# Altium schematic sheet Single Channel Isolated Gate Driver Test Board.SchDot
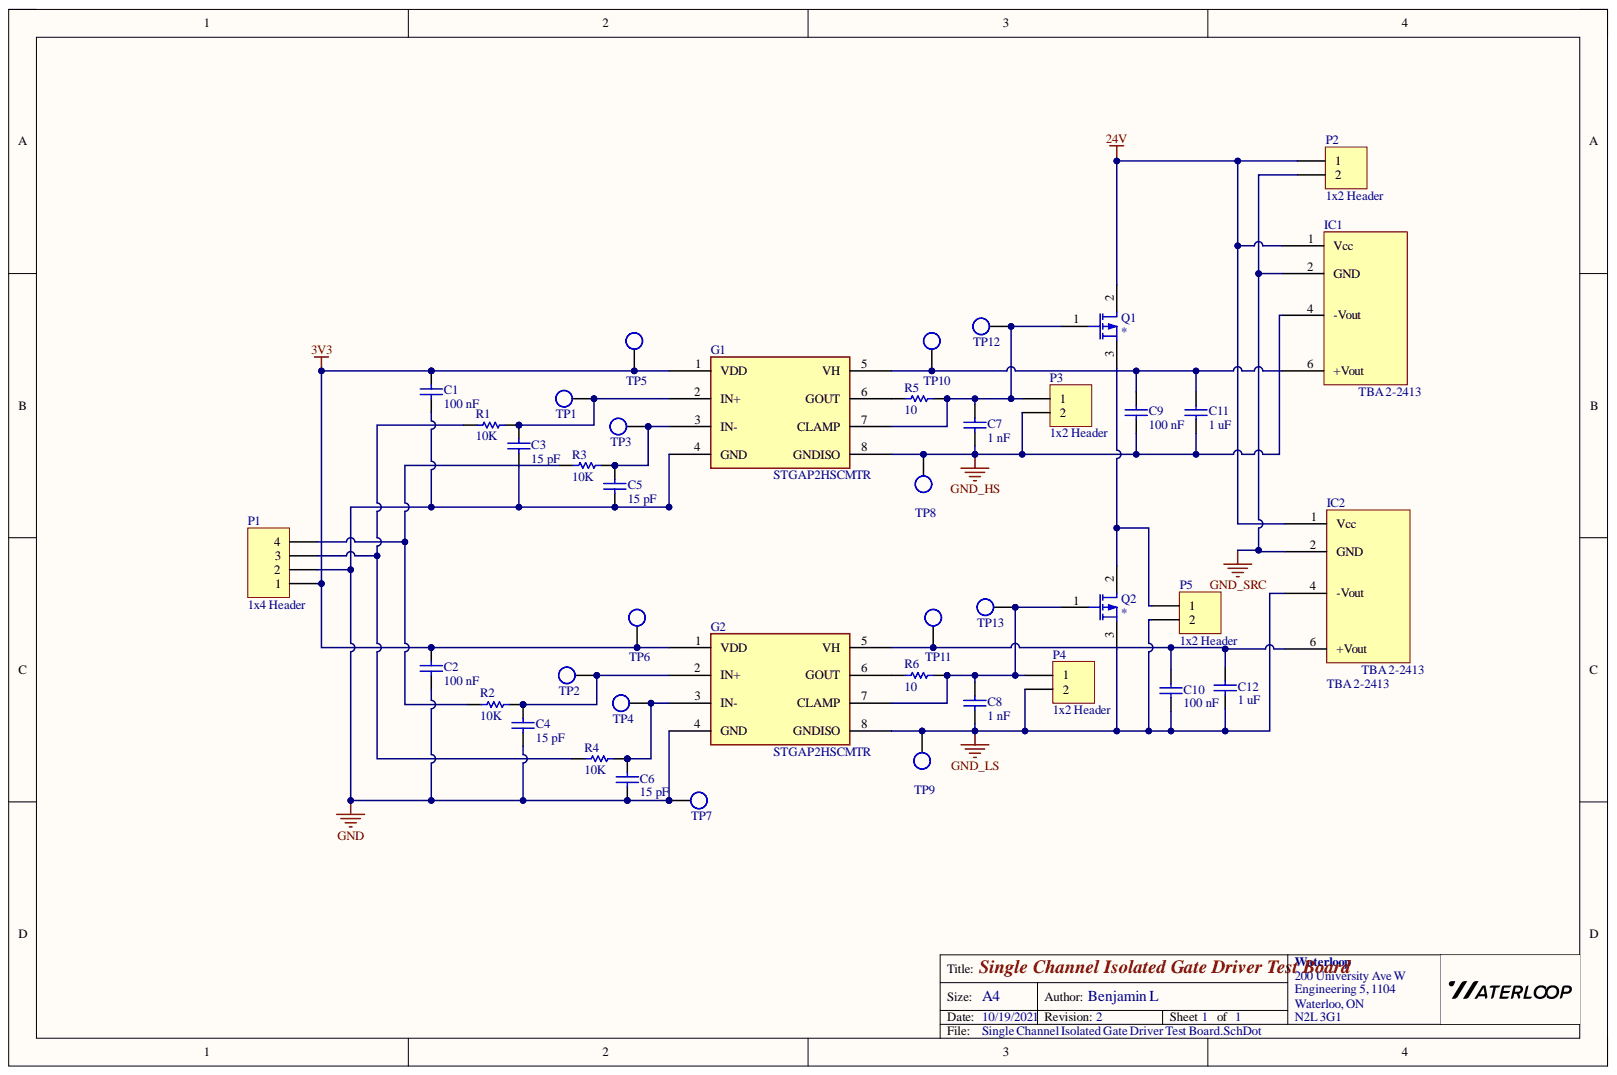
[diagram p0: Single Channel Isolated Gate Driver Test Board.SchDot]

## Parts
C1  value 100 nF  [0805 - Capacitor]  (hidden comment: Capacitor - Nonpolarized)
C2  value 100 nF  [0805 - Capacitor]  (hidden comment: Capacitor - Nonpolarized)
C3  value 15 pF  [0805 - Capacitor]  (hidden comment: Capacitor - Nonpolarized)
C4  value 15 pF  [0805 - Capacitor]  (hidden comment: Capacitor - Nonpolarized)
C5  value 15 pF  [0805 - Capacitor]  (hidden comment: Capacitor - Nonpolarized)
C6  value 15 pF  [0805 - Capacitor]  (hidden comment: Capacitor - Nonpolarized)
C7  value 1 nF  [0805 - Capacitor]  (hidden comment: Capacitor - Nonpolarized)
C8  value 1 nF  [0805 - Capacitor]  (hidden comment: Capacitor - Nonpolarized)
C9  value 100 nF  [0805 - Capacitor]  (hidden comment: Capacitor - Nonpolarized)
C10  value 100 nF  [0805 - Capacitor]  (hidden comment: Capacitor - Nonpolarized)
C11  value 1 uF  [0805 - Capacitor]  (hidden comment: Capacitor - Nonpolarized)
C12  value 1 uF  [0805 - Capacitor]  (hidden comment: Capacitor - Nonpolarized)
G1  STGAP2HSCMTR  [STGAP2HSCMTR]
G2  STGAP2HSCMTR  [STGAP2HSCMTR]
IC1  TBA 2-2413  [TBA 2-2413]
IC2  TBA 2-2413  [TBA 2-2413]
P1  1x4 Header  [HDR1X4]
P2  1x2 Header  [HDR1X2]
P3  1x2 Header  [HDR1X2]
P4  1x2 Header  [HDR1X2]
P5  1x2 Header  [HDR1X2]
Q1  MOSFET-P  [TO2520AB]  (value not drawn)
Q2  MOSFET-P  [TO2520AB]  (value not drawn)
R1  value 10K  [0603 - Resistor]  (hidden comment: Resistor)
R2  value 10K  [0603 - Resistor]  (hidden comment: Resistor)
R3  value 10K  [0603 - Resistor]  (hidden comment: Resistor)
R4  value 10K  [0603 - Resistor]  (hidden comment: Resistor)
R5  value 10  [1206 - Resistor]  (hidden comment: Resistor)
R6  value 10  [1206 - Resistor]  (hidden comment: Resistor)
TP1  Test Point  [Test Point]  (value not drawn)  (no BOM)
TP2  Test Point  [Test Point]  (value not drawn)  (no BOM)
TP3  Test Point  [Test Point]  (value not drawn)  (no BOM)
TP4  Test Point  [Test Point]  (value not drawn)  (no BOM)
TP5  Test Point  [Test Point]  (value not drawn)  (no BOM)
TP6  Test Point  [Test Point]  (value not drawn)  (no BOM)
TP7  Test Point  [Test Point]  (value not drawn)  (no BOM)
TP8  Test Point  [Test Point]  (value not drawn)  (no BOM)
TP9  Test Point  [Test Point]  (value not drawn)  (no BOM)
TP10  Test Point  [Test Point]  (value not drawn)  (no BOM)
TP11  Test Point  [Test Point]  (value not drawn)  (no BOM)
TP12  Test Point  [Test Point]  (value not drawn)  (no BOM)
TP13  Test Point  [Test Point]  (value not drawn)  (no BOM)

## Nets
3V3: C1.1 C2.1 G1.1(VDD) G2.1(VDD) P1.1 TP5.1 TP6.1
24V: IC1.1(Vcc) IC2.1(Vcc) P2.1 Q1.2
GND: C1.2 C2.2 C3.1 C4.1 C5.1 C6.1 G1.4(GND) G2.4(GND) P1.2 TP7.1
GND_HS: C7.1 C9.1 C11.1 G1.8(GNDISO) IC1.4(-Vout) P3.2 TP8.1
GND_LS: C8.1 C10.1 C12.1 G2.8(GNDISO) IC2.4(-Vout) P4.2 P5.2 Q2.3 TP9.1
GND_SRC: IC1.2(GND) IC2.2(GND) P2.2
NetC3_2: C3.2 G1.2(IN+) R1.2 TP1.1
NetC4_2: C4.2 G2.2(IN+) R2.2 TP2.1
NetC5_2: C5.2 G1.3(IN-) R3.2 TP3.1
NetC6_2: C6.2 G2.3(IN-) R4.2 TP4.1
NetC7_2: C7.2 G1.7(CLAMP) P3.1 Q1.1 R5.2 TP12.1
NetC8_2: C8.2 G2.7(CLAMP) P4.1 Q2.1 R6.2 TP13.1
NetC9_2: C9.2 C11.2 G1.5(VH) IC1.6(+Vout) TP10.1
NetC10_2: C10.2 C12.2 G2.5(VH) IC2.6(+Vout) TP11.1
NetG1_6: G1.6(GOUT) R5.1
NetG2_6: G2.6(GOUT) R6.1
NetP1_3: P1.3 R1.1 R4.1
NetP1_4: P1.4 R2.1 R3.1
NetP5_1: P5.1 Q1.3 Q2.2

## Library data of the parts
C1 C2 C9 C10 = Capacitor - Nonpolarized: Nonpolarized Capacitor; Value: 100 nF; Component Kind: Standard; Library Name: General.SchLib; Signal Integrity: Cap; Simulation: CAP
C3 C6 = Capacitor - Nonpolarized: Nonpolarized Capacitor; Value: 15 pF; Component Kind: Standard; Library Name: General.SchLib; Signal Integrity: Cap; Simulation: CAP
C4 C5 C7 C8 C11 C12 = Capacitor - Nonpolarized: Nonpolarized Capacitor; Component Kind: Standard; Library Name: General.SchLib; Signal Integrity: Cap; Simulation: CAP
IC1 IC2 = TBA 2-2413: DC DC CONVERTER 15V 2W; Component Kind: Standard; Library Name: MC.SchLib
P1 = 1x4 Header: 1x4 Pin Header; Component Kind: Standard; Library Name: GeneralConnectors.SchLib; Signal Integrity: Connector
P2-P5 = 1x2 Header: 1x2 Pin Header; Component Kind: Standard; Library Name: GeneralConnectors.SchLib; Signal Integrity: Connector
Q1 Q2 = MOSFET-P: P-Channel MOSFET; Component Kind: Standard; Library Name: General.SchLib; Simulation: PMOS
G1 G2 = STGAP2HSCMTR: Component Kind: Standard; Library Name: General.SchLib
R1-R6 = Resistor: Component Kind: Standard; Description: Resistor; Library Name: General.SchLib; Signal Integrity: Res; Simulation: RESISTOR
TP1-TP13 = Test Point: Description: Test Point; Library Name: General.SchLib
